# Altium schematic sheet Sheet2.SchDoc(Sheet2)
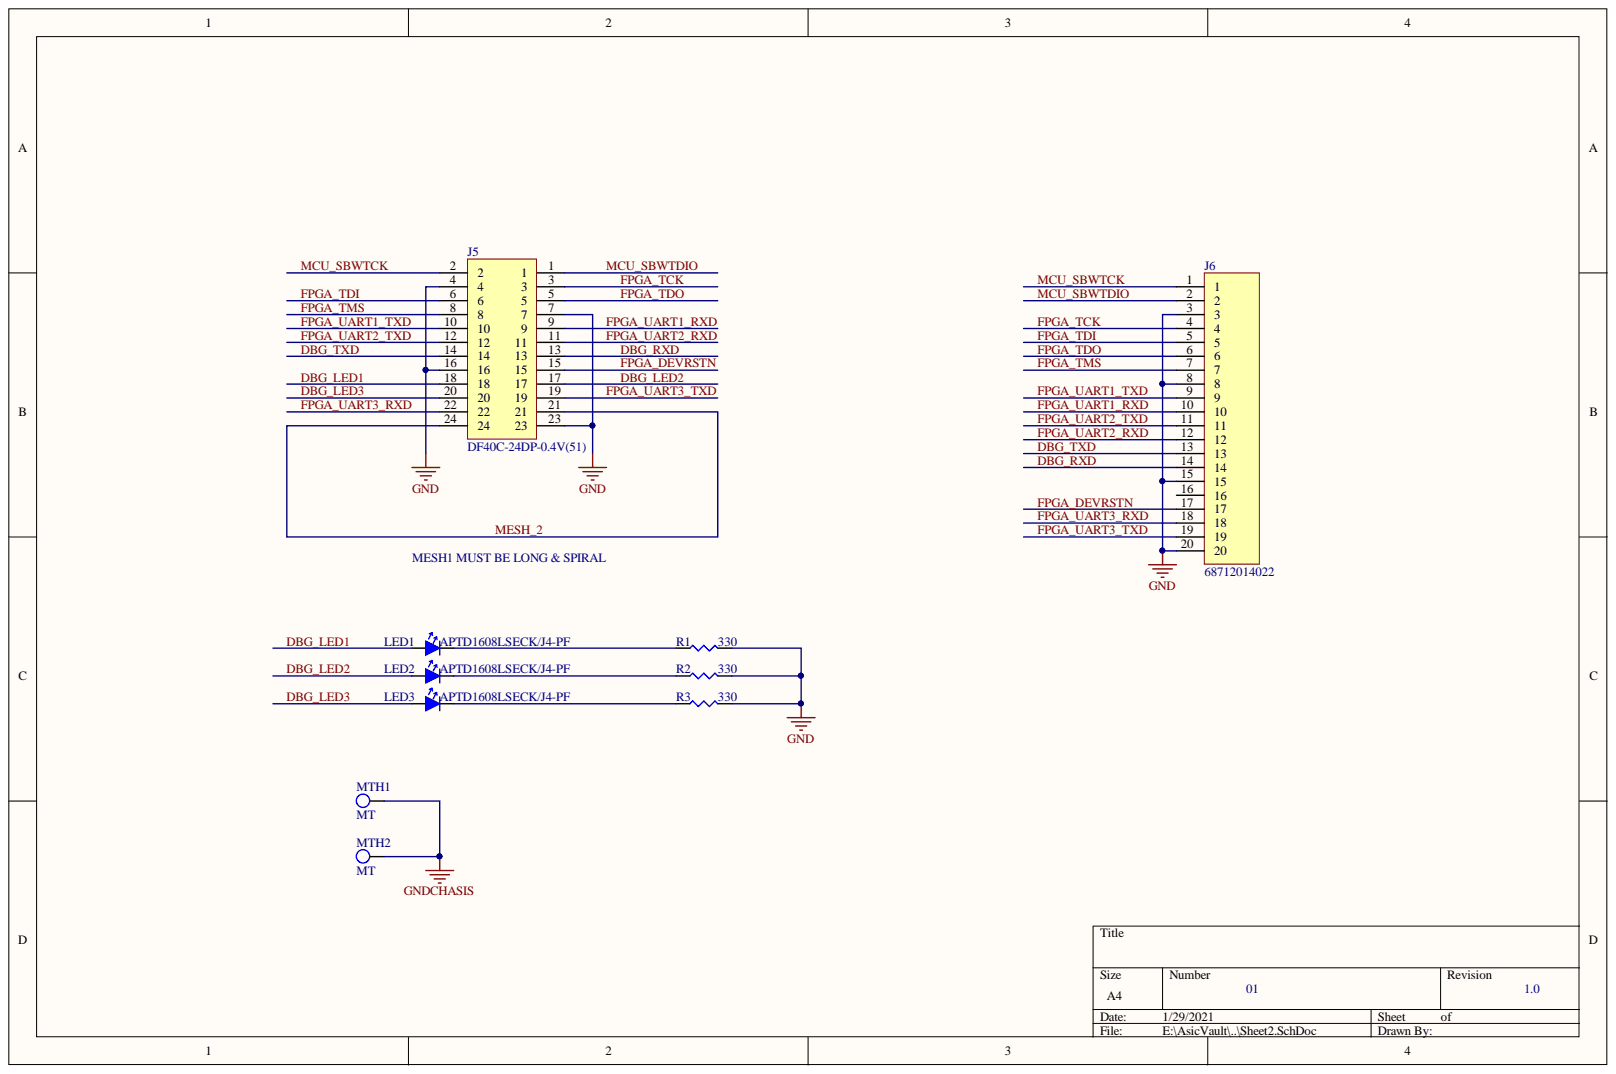
[diagram p0: Sheet2.SchDoc]

## Parts
J5  DF40C-24DP-0.4V(51)  [DF40C-24DP-0.4V(51)]
J6  68712014022  [ZIF_0.5MM_20P]
LED1  APTD1608LSECK/J4-PF  [LED0603]
LED2  APTD1608LSECK/J4-PF  [LED0603]
LED3  APTD1608LSECK/J4-PF  [LED0603]
MTH1  MT  [MTHX]
MTH2  MT  [MTHX]
R1  330  [R0201]
R2  330  [R0201]
R3  330  [R0201]

## Nets
GND: J5.4 J5.7 J5.16 J5.23 J6.3 J6.8 J6.15 J6.20 R1.2 R2.2 R3.2
GNDCHASIS: MTH1.1 MTH2.1
DBG_LED1: J5.18 LED1.2
DBG_LED2: J5.17 LED2.2
DBG_LED3: J5.20 LED3.2
DBG_RXD: J5.13 J6.14
DBG_TXD: J5.14 J6.13
FPGA_DEVRSTN: J5.15 J6.17
FPGA_TCK: J5.3 J6.4
FPGA_TDI: J5.6 J6.5
FPGA_TDO: J5.5 J6.6
FPGA_TMS: J5.8 J6.7
FPGA_UART1_RXD: J5.9 J6.10
FPGA_UART1_TXD: J5.10 J6.9
FPGA_UART2_RXD: J5.11 J6.12
FPGA_UART2_TXD: J5.12 J6.11
FPGA_UART3_RXD: J5.22 J6.18
FPGA_UART3_TXD: J5.19 J6.19
MCU_SBWTCK: J5.2 J6.1
MCU_SBWTDIO: J5.1 J6.2
MESH_2: J5.21 J5.24
NetLED1_1: LED1.1 R1.1
NetLED2_1: LED2.1 R2.1
NetLED3_1: LED3.1 R3.1

Not connected: J6 16

## Library data of the parts
J5 = DF40C-24DP-0.4V(51): Description 1: CONN HDR 24POS 0.4MM SMD GOLD; Supplier Part Number 1: H11620CT-ND; Supplier 1: Digi-Key; Component Kind: Standard; Library Name: AMOLED.SchLib
J6 = 68712014022: Description 1: CONN FPC TOP 20POS 0.50MM R/A; Supplier Part Number 1: 732-2023-6-ND; Supplier 1: Digi-Key; Component Kind: Standard; Library Name: AMOLED.SchLib
LED1-LED3 = LED_ORGN_0603_KB: Description 1: LED ORANGE CLEAR 0603 SMD; Supplier Part Number 1: 754-1946-1-ND; Supplier 1: Digi-Key; Library Name: Control_Board.SchLib; Component Kind: Standard
MTH1 MTH2 = TP: Library Name: Control_Board.SchLib; Component Kind: Standard
R1-R3 = 330/1%/0201: Description 1: RES SMD 330 OHM 1% 1/20W 0201; Supplier Part Number 1: YAG2604CT-ND; Supplier 1: Digi-Key; Library Name: Control_Board.SchLib; Component Kind: Standard
